# Altium schematic sheet EVB-NORA-B2_SCHEM_0005_On-Board-Debug.SchDoc(EVB-NORA-B2_SCHEM_0005_On-Board-Debug)
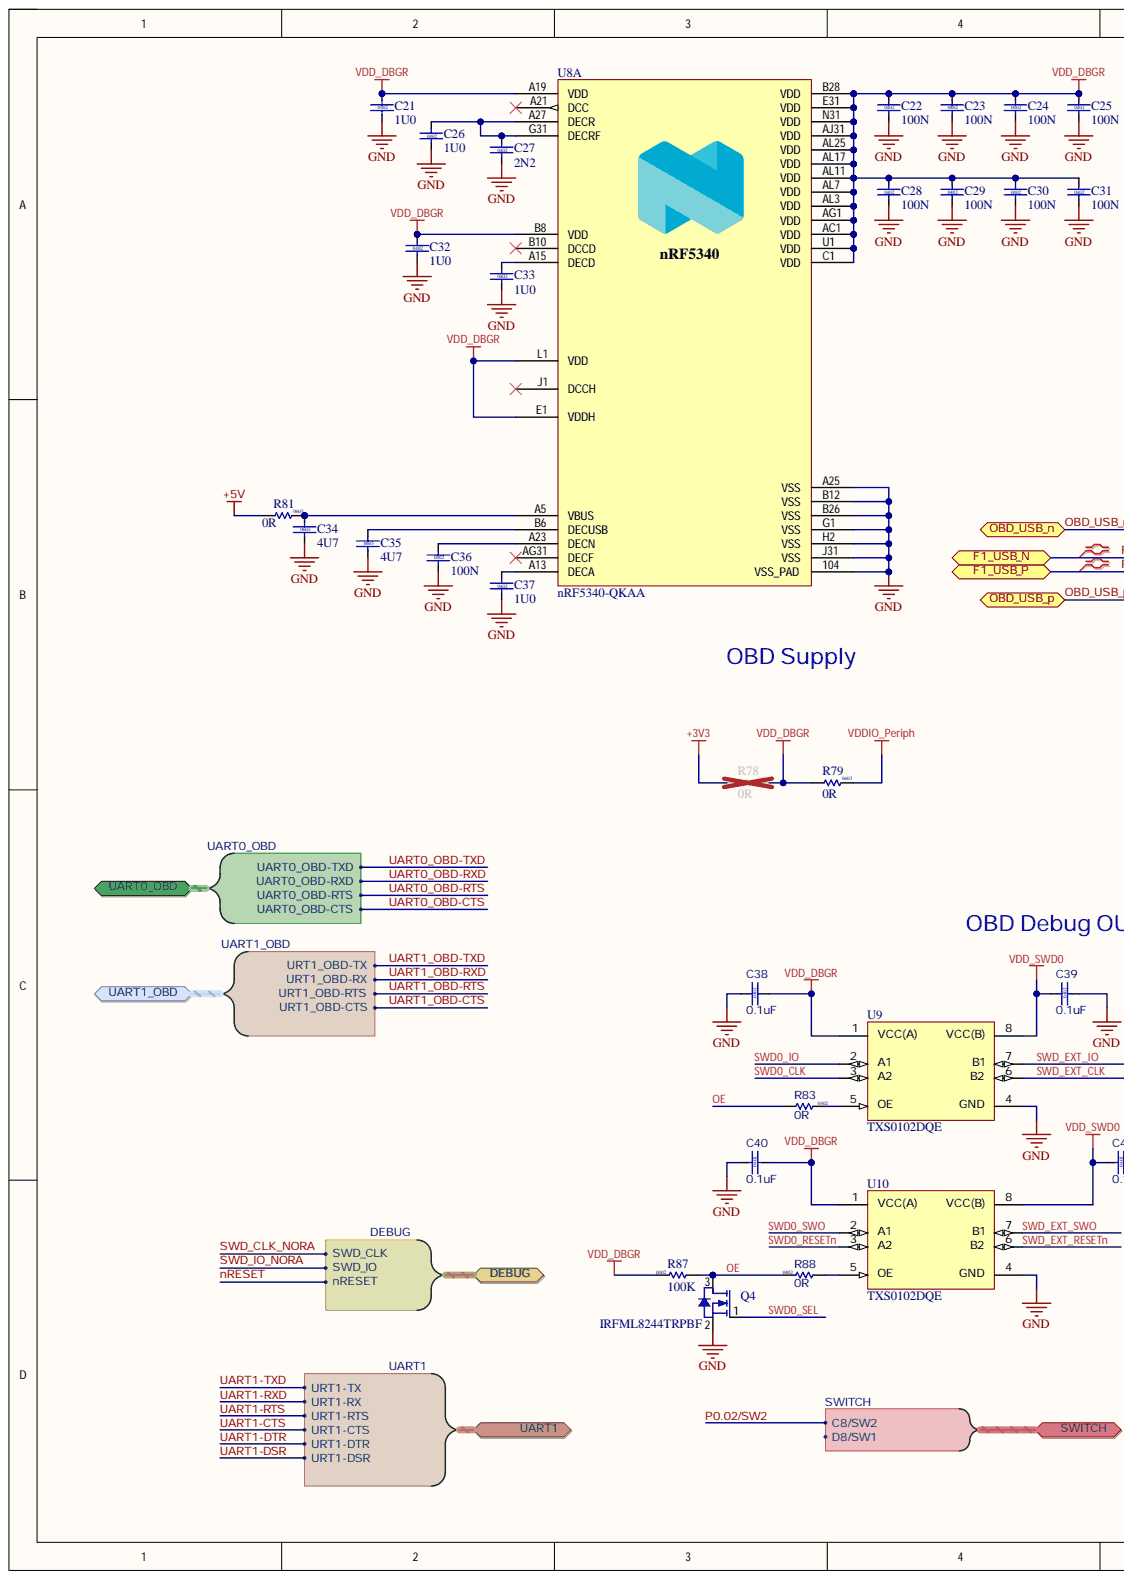
[diagram p0: EVB-NORA-B2_SCHEM_0005_On-Board-Debug.SchDoc, left]
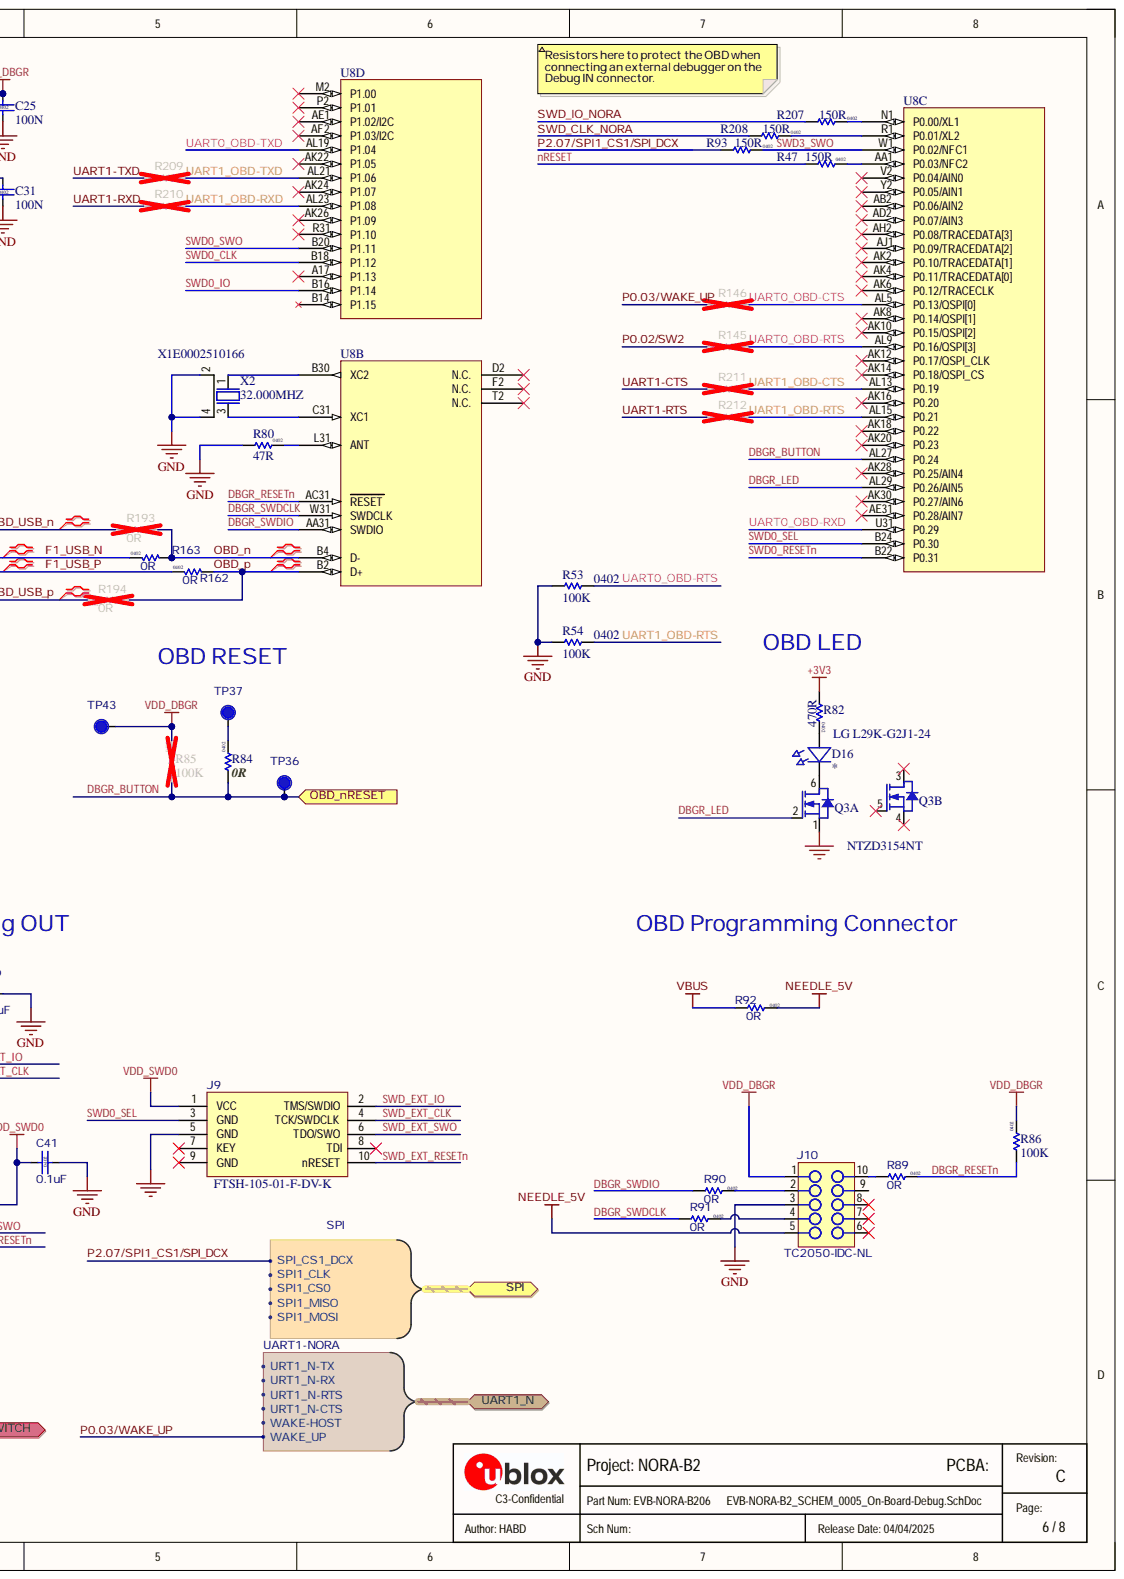
[diagram p1: EVB-NORA-B2_SCHEM_0005_On-Board-Debug.SchDoc, right]

## Parts
C21  value 1U0  Size: 0402  [Cap_0402_1100Lx600Wx600H_600x600Pad_350G_FLX]  (hidden comment: UBXH01-2021003)
C22  value 100N  Size: 0402  [Cap 0402 HD]  (hidden comment: UBXH01-2021002)
C23  value 100N  Size: 0402  [Cap 0402 HD]  (hidden comment: UBXH01-2021002)
C24  value 100N  Size: 0402  [Cap 0402 HD]  (hidden comment: UBXH01-2021002)
C25  value 100N  Size: 0402  [Cap 0402 HD]  (hidden comment: UBXH01-2021002)
C26  value 1U0  Size: 0402  [Cap_0402_1100Lx600Wx600H_600x600Pad_350G_FLX]  (hidden comment: UBXH01-2021003)
C27  value 2N2  Size: 0402  [Cap_0402_1100Lx600Wx600H_600x600Pad_350G_FLX]  (hidden comment: UBXH01-0023017)
C28  value 100N  Size: 0402  [Cap 0402 HD]  (hidden comment: UBXH01-2021002)
C29  value 100N  Size: 0402  [Cap 0402 HD]  (hidden comment: UBXH01-2021002)
C30  value 100N  Size: 0402  [Cap 0402 HD]  (hidden comment: UBXH01-2021002)
C31  value 100N  Size: 0402  [Cap 0402 HD]  (hidden comment: UBXH01-2021002)
C32  value 1U0  Size: 0402  [Cap_0402_1100Lx600Wx600H_600x600Pad_350G_FLX]  (hidden comment: UBXH01-2021003)
C33  value 1U0  Size: 0402  [Cap_0402_1100Lx600Wx600H_600x600Pad_350G_FLX]  (hidden comment: UBXH01-2021003)
C34  value 4U7  Size: 0603  [CAP_0603_1750Lx950Wx850H_600x1000Pad_900G_FLX]  (hidden comment: UBXH01-2002003)
C35  value 4U7  Size: 0603  [CAP_0603_1750Lx950Wx850H_600x1000Pad_900G_FLX]  (hidden comment: UBXH01-2002003)
C36  value 100N  Size: 0402  [Cap 0402 HD]  (hidden comment: UBXH01-2021002)
C37  value 1U0  Size: 0402  [Cap_0402_1100Lx600Wx600H_600x600Pad_350G_FLX]  (hidden comment: UBXH01-2021003)
C38  value 0.1uF  Size: 0402  [Cap 0402 HD]  (hidden comment: 120-00016)
C39  value 0.1uF  Size: 0402  [Cap 0402 HD]  (hidden comment: 120-00016)
C40  value 0.1uF  Size: 0402  [Cap 0402 HD]  (hidden comment: 120-00016)
C41  value 0.1uF  Size: 0402  [Cap 0402 HD]  (hidden comment: 120-00016)
D16  Manufacturer P/N: LG L29K-G2J1-24  [LED_0603_1600Lx800Wx400H_600x800Pad_800G_FLX]  (hidden comment: UBXH11-0000018)
J9  Manufacturer P/N: FTSH-105-01-F-DV-K  [HDR.050P-10Pin-2x5-SMT_Key]  (hidden comment: 130-00099)
J10  TC2050-IDC-NL  [TC2050-IDC-NL]  (no BOM)
Q3  value NTZD3154NT  Manufacturer P/N: NTZD3154NT  [SOT-563]  (hidden comment: UBXH20-0000083)  (parts A,B)
Q4  value IRFML8244TRPBF  Manufacturer P/N: IRFML8244TRPBF  [SOT-23]  (hidden comment: UBXH20-0000036)
R47  value 150R  Size: 0402  [RES_0402_1100Lx600Wx400H_500x600Pad_400G_FLX]  (hidden comment: UBXH18-0008029)
R53  value 100K  Size: 0402  [RES 0402 HD]
R54  value 100K  Size: 0402  [RES 0402 HD]
R78  value 0R  Size: 0603  [RES_0603_1700Lx950Wx600H_600x1000Pad_800G_FLX]
R79  value 0R  Size: 0603  [RES_0603_1700Lx950Wx600H_600x1000Pad_800G_FLX]  (hidden comment: UBXH18-0003001)
R80  value 47R  Size: 0402  [RES_0402_1100Lx600Wx400H_500x600Pad_400G_FLX]  (hidden comment: UBXH18-0008017)
R81  value 0R  Size: 0603  [RES_0603_1700Lx950Wx600H_600x1000Pad_800G_FLX]  (hidden comment: UBXH18-0003001)
R82  value 470R  Size: 0402  [RES_0402_1100Lx600Wx400H_500x600Pad_400G_FLX]  (hidden comment: UBXH18-0008041)
R83  value 0R  Size: 0402  [RES 0402 HD]  (hidden comment: UBXH18-0003002)
R84  value 0R  Size: 0402  [RES_0402_1100Lx600Wx400H_500x600Pad_400G_FLX]
R84
R84
R84
R84
R85  value 100K  Size: 0402  [RES 0402 HD]
R86  value 100K  Size: 0402  [RES 0402 HD]  (hidden comment: UBXH18-0000005)
R87  value 100K  Size: 0402  [RES 0402 HD]  (hidden comment: UBXH18-0000005)
R88  value 0R  Size: 0402  [RES 0402 HD]  (hidden comment: UBXH18-0003002)
R89  value 0R  Size: 0402  [RES 0402 HD]  (hidden comment: UBXH18-0003002)
R90  value 0R  Size: 0402  [RES 0402 HD]  (hidden comment: UBXH18-0003002)
R91  value 0R  Size: 0402  [RES 0402 HD]  (hidden comment: UBXH18-0003002)
R92  value 0R  Size: 0402  [RES 0402 HD]  (hidden comment: UBXH18-0003002)
R93  value 150R  Size: 0402  [RES_0402_1100Lx600Wx400H_500x600Pad_400G_FLX]  (hidden comment: UBXH18-0008029)
R145  = "Part Number" + Value + DNP  [RES 0402 HD]
R146  = "Part Number" + Value + DNP  [RES 0402 HD]
R162  value 0R  Size: 0402  [RES 0402 HD]
R163  value 0R  Size: 0402  [RES 0402 HD]
R193  value 0R  Size: 0402  [RES 0402 HD]
R194  value 0R  Size: 0402  [RES 0402 HD]
R207  value 150R  Size: 0402  [RES_0402_1100Lx600Wx400H_500x600Pad_400G_FLX]  (hidden comment: UBXH18-0008029)
R208  value 150R  Size: 0402  [RES_0402_1100Lx600Wx400H_500x600Pad_400G_FLX]  (hidden comment: UBXH18-0008029)
R209  UBXH18-0003002  [RES 0402 HD]  (value not drawn)
R210  UBXH18-0003002  [RES 0402 HD]  (value not drawn)
R211  UBXH18-0003002  [RES 0402 HD]  (value not drawn)
R212  UBXH18-0003002  [RES 0402 HD]  (value not drawn)
TP36  TP10R  [TP10R]  (value not drawn)  (no BOM)
TP37  TP10R  [TP10R]  (value not drawn)  (no BOM)
TP43  TP10R  [TP10R]  (value not drawn)  (no BOM)
U8  value nRF5340-QKAA  Manufacturer P/N: nRF5340-QKAA  [nRF5340_AQFN94]  (hidden comment: UBXH12-0000420)  (parts A,B,C,D)
U9  value TXS0102DQE  Manufacturer P/N: TXS0102DQE  [DQE0008A]  (hidden comment: UBXH10-0000108)
U10  value TXS0102DQE  Manufacturer P/N: TXS0102DQE  [DQE0008A]  (hidden comment: UBXH10-0000108)
X2  value 32.000MHZ  Manufacturer P/N: X1E0002510166  [XTAL_1612]  (hidden comment: UBXH21-0000240)

## Ports
DEBUG, DEBUG.nRESET, DEBUG.SWD_CLK, DEBUG.SWD_IO, F1_USB_N, F1_USB_P, OBD_nRESET, OBD_USB_n, OBD_USB_p, SPI, SPI.SPI1_CLK, SPI.SPI1_CS0, SPI.SPI1_MISO, SPI.SPI1_MOSI, SPI.SPI_CS1_DCX, SWITCH, SWITCH.C8/SW2, SWITCH.D8/SW1, UART0_OBD, UART0_OBD.UART0_OBD-CTS, UART0_OBD.UART0_OBD-RTS, UART0_OBD.UART0_OBD-RXD, UART0_OBD.UART0_OBD-TXD, UART1, UART1.URT1-CTS, UART1.URT1-DSR, UART1.URT1-DTR, UART1.URT1-RTS, UART1.URT1-RX, UART1.URT1-TX, UART1_N, UART1_N.URT1_N-CTS, UART1_N.URT1_N-RTS, UART1_N.URT1_N-RX, UART1_N.URT1_N-TX, UART1_N.WAKE-HOST, UART1_N.WAKE_UP, UART1_OBD, UART1_OBD.URT1_OBD-CTS, UART1_OBD.URT1_OBD-RTS, UART1_OBD.URT1_OBD-RX, UART1_OBD.URT1_OBD-TX

## Nets
+3V3: R78.1 R82.1
+5V: R81.1
GND: C21.2 C22.2 C23.2 C24.2 C25.2 C26.2 C27.2 C28.2 C29.2 C30.2 C31.2 C32.2 C33.2 C34.2 C35.2 C36.2 C37.2 C38.1 C39.2 C40.1 C41.2 J9.5(GND) J10.3 Q3.1 Q4.2 R53.1 R54.1 R80.1 U8.104(VSS_PAD) U8.A25(VSS) U8.B12(VSS) U8.B26(VSS) U8.G1(VSS) U8.H2(VSS) U8.J31(VSS) U9.4(GND) U10.4(GND) X2.2 X2.4
NEEDLE_5V: J10.5 R92.1
VBUS: R92.2
VDD_DBGR: C21.1 C22.1 C23.1 C24.1 C25.1 C28.1 C29.1 C30.1 C31.1 C32.1 C38.2 C40.2 J10.1 R78.2 R79.1 R85.2 R86.2 R87.2 TP43.1 U8.A19(VDD) U8.AC1(VDD) U8.AG1(VDD) U8.AJ31(VDD) U8.AL3(VDD) U8.AL7(VDD) U8.AL11(VDD) U8.AL17(VDD) U8.AL25(VDD) U8.B8(VDD) U8.B28(VDD) U8.C1(VDD) U8.E1(VDDH) U8.E31(VDD) U8.L1(VDD) U8.N31(VDD) U8.U1(VDD) U9.1(VCC(A)) U10.1(VCC(A))
VDD_SWD0: C39.1 C41.1 J9.1(VCC) U9.8(VCC(B)) U10.8(VCC(B))
VDDIO_Periph: R79.2
DBGR_BUTTON: R84.1 R85.1 TP36.1 U8.AL27(P0.24) ; port OBD_nRESET
DBGR_LED: Q3.2 U8.AL29(P0.26/AIN5)
DBGR_RESETn: R86.1 R89.1 U8.AC31(RESET)
DBGR_SWDCLK: R91.2 U8.W31(SWDCLK)
DBGR_SWDIO: R90.2 U8.AA31(SWDIO)
F1_USB_N: R163.2 ; port F1_USB_N
F1_USB_P: R162.2 ; port F1_USB_P
nRESET: R47.1 ; port DEBUG
OBD_n: R163.1 R193.1 U8.B4(D-)
OBD_p: R162.1 R194.1 U8.B2(D+)
OBD_USB_n: R193.2 ; port OBD_USB_n
OBD_USB_p: R194.2 ; port OBD_USB_p
OE: Q4.3 R83.2 R87.1 R88.1
P0.02/SW2: R145.2 ; port SWITCH
P0.03/WAKE_UP: R146.2 ; port UART1_N
P2.07/SPI1_CS1/SPI_DCX: R93.1 ; port SPI
SWD0_CLK: U8.B18(P1.12) U9.3(A2)
SWD0_IO: U8.B16(P1.14) U9.2(A1)
SWD0_RESETn: U8.B22(P0.31) U10.3(A2)
SWD0_SEL: J9.3(GND) Q4.1 U8.B24(P0.30)
SWD0_SWO: U8.B20(P1.11) U10.2(A1)
SWD3_SWO: R93.2 U8.W1(P0.02/NFC1)
SWD_CLK_NORA: R208.1 ; port DEBUG
SWD_EXT_CLK: J9.4(TCK/SWDCLK) U9.6(B2)
SWD_EXT_IO: J9.2(TMS/SWDIO) U9.7(B1)
SWD_EXT_RESETn: J9.10(nRESET) U10.6(B2)
SWD_EXT_SWO: J9.6(TDO/SWO) U10.7(B1)
SWD_IO_NORA: R207.1 ; port DEBUG
UART0_OBD-CTS: R146.1 U8.AL5(P0.13/QSPI[0]) ; port UART0_OBD
UART0_OBD-RTS: R53.2 R145.1 U8.AL9(P0.16/QSPI[3]) ; port UART0_OBD
UART0_OBD-RXD: U8.U31(P0.29) ; port UART0_OBD
UART0_OBD-TXD: U8.AL19(P1.04) ; port UART0_OBD
UART1-CTS: R211.2 ; port UART1
UART1-RTS: R212.2 ; port UART1
UART1-RXD: R210.2 ; port UART1
UART1-TXD: R209.2 ; port UART1
UART1_OBD-CTS: R211.1 U8.AL13(P0.19) ; port UART1_OBD
UART1_OBD-RTS: R54.2 R212.1 U8.AL15(P0.21) ; port UART1_OBD
UART1_OBD-RXD: R210.1 U8.AL23(P1.08) ; port UART1_OBD
UART1_OBD-TXD: R209.1 U8.AL21(P1.06) ; port UART1_OBD
NetC26_1: C26.1 C27.1 U8.A27(DECR) U8.G31(DECRF)
NetC33_1: C33.1 U8.A15(DECD)
NetC34_1: C34.1 R81.2 U8.A5(VBUS)
NetC35_1: C35.1 U8.B6(DECUSB)
NetC36_1: C36.1 U8.A23(DECN)
NetC37_1: C37.1 U8.A13(DECA)
NetD16_1: D16.1 Q3.6
NetD16_2: D16.2 R82.2
NetJ10_2: J10.2 R90.1
NetJ10_4: J10.4 R91.1
NetJ10_10: J10.10 R89.2
NetR47_2: R47.2 U8.AA1(P0.03/NFC2)
NetR80_2: R80.2 U8.L31(ANT)
NetR83_1: R83.1 U9.5(OE)
NetR84_2: R84.2 TP37.1
NetR88_2: R88.2 U10.5(OE)
NetR207_2: R207.2 U8.N1(P0.00/XL1)
NetR208_2: R208.2 U8.R1(P0.01/XL2)
NetU8_B30: U8.B30(XC2) X2.1
NetU8_C31: U8.C31(XC1) X2.3
N00001: ; port SPI
N00003: ; port SPI
N00005: ; port SPI
N00007: ; port SPI
N00012: ; port SWITCH
N00013: ; port UART1_N
N00014: ; port UART1_N
N00015: ; port UART1_N
N00016: ; port UART1_N
N00017: ; port UART1_N
UART1-DSR: ; port UART1
UART1-DTR: ; port UART1

Not connected: J9 7(KEY) 8(TDI) 9(GND); J10 6 7 8 9; Q3 3 4 5; U8 A17(P1.13) A21(DCC) AB2(P0.06/AIN2) AD2(P0.07/AIN3) AE1(P1.02/I2C) AE31(P0.28/AIN7) AF2(P1.03/I2C) AG31(DECF) AH2(P0.08/TRACEDATA[3]) AJ1(P0.09/TRACEDATA[2]) AK2(P0.10/TRACEDATA[1]) AK4(P0.11/TRACEDATA[0]) AK6(P0.12/TRACECLK) AK8(P0.14/QSPI[1]) AK10(P0.15/QSPI[2]) AK12(P0.17/QSPI_CLK) AK14(P0.18/QSPI_CS) AK16(P0.20) AK18(P0.22) AK20(P0.23) AK22(P1.05) AK24(P1.07) AK26(P1.09) AK28(P0.25/AIN4) AK30(P0.27/AIN6) B10(DCCD) B14(P1.15) D2(N.C.) F2(N.C.) J1(DCCH) M2(P1.00) P2(P1.01) R31(P1.10) T2(N.C.) V2(P0.04/AIN0) Y2(P0.05/AIN1)

## Library data of the parts
C21 C26 C32 C33 C37 = UBXH01-2021003: CAP CER X5R 0402 1U0 10% 6.3V; Manufacturer: Murata Manufacturing Company, Ltd.; Manufacturer P/N: GRM155R61C105KA12; Database Table Name: Passive - Capacitor; Editor: philippe.largier; Env: GREEN; Mfg P/N Released: Yes; Mfg P/N Split: 100; Mfg P/N Status: Active; Perferred: PREF; Permitted Use: Volume Products; Released by: alvaro.nussbaum; Component Kind: Standard; Library Name: UBX_Parts_DB_Lib.DbLib; Manufacturer 2: Samsung Electro-Mechanics; Manufacturer 3: Taiyo Yuden Company, Ltd.; Manufacturer P/N 2: CL05A105KA5NNN; Manufacturer P/N 3: JMK105BJ105KV-F; Max Voltage: 6.3V; Mfg P/N Datasheet: https://wiki.u-blox.com/bin/view/Generic/Docs/DocumentSummary?index=29581; Mfg P/N Datasheet 2: https://wiki.u-blox.com/bin/view/Generic/Docs/DocumentSummary?index=28197; Mfg P/N Datasheet 3: https://wiki.u-blox.com/bin/view/Generic/Docs/DocumentSummary?index=20234; Mfg P/N Released 2: Yes; Mfg P/N Released 3: Yes; Mfg P/N Split 2: 0; Mfg P/N Split 3: 0; Mfg P/N Status 2: Active; Mfg P/N Status 3: NRND; Part Number: UBXH01-2021003; Part Type: Capacitor; RefDes: C; Release Data: 2016-02-02T16:25:07; Signal Integrity: Cap Semi; Simulation: CAP; Tolerance: 10%; UBX Wiki: https://wiki.u-blox.com/bin/view/Operations/PartDetails?index=5761
C22-C25 C28-C31 C36 = UBXH01-2021002: CAP CER X5R 0402 100N 10% 10V; Manufacturer: Murata Manufacturing Company, Ltd.; Manufacturer P/N: GRM155R61A104KA01; Database Table Name: Passive - Capacitor; Editor: roland.rust; Env: GREEN; Mfg P/N Released: Yes; Mfg P/N Split: 100; Mfg P/N Status: NRND; Perferred: PREF; Permitted Use: Volume Products; Component Kind: Standard; Library Name: UBX_Parts_DB_Lib.DbLib; Manufacturer 2: Samsung Electro-Mechanics; Manufacturer P/N 2: CL05A104KP5NNN; Max Voltage: 10V; Mfg P/N Datasheet 2: https://wiki.u-blox.com/bin/view/Generic/Docs/DocumentSummary?index=28113; Mfg P/N Released 2: Yes; Mfg P/N Split 2: 0; Mfg P/N Status 2: Active; Part Number: UBXH01-2021002; Part Type: Capacitor; RefDes: C; Release Data: 2005-07-22T13:59:44; Signal Integrity: Cap Semi; Simulation: CAP; Tolerance: 10%; UBX Wiki: https://wiki.u-blox.com/bin/view/Operations/PartDetails?index=5759; Released by: roland.rust
C27 = UBXH01-0023017: CAP CER X7R 0402 2N2 10% 16V; Manufacturer: Murata Manufacturing Company, Ltd.; Manufacturer P/N: GRM155R71C222KA01; Database Table Name: Passive - Capacitor; Editor: roland.rust; Env: ROHS; Mfg P/N Released: Yes; Mfg P/N Split: 100; Mfg P/N Status: NRND; Perferred: PREF; Permitted Use: Volume Products; Component Kind: Standard; Library Name: UBX_Parts_DB_Lib.DbLib; Max Voltage: 16V; Part Number: UBXH01-0023017; Part Type: Capacitor; RefDes: C; Release Data: 2005-07-22T13:59:44; Signal Integrity: Cap Semi; Simulation: CAP; Tolerance: 10%; UBX Wiki: https://wiki.u-blox.com/bin/view/Operations/PartDetails?index=5475; Released by: roland.rust
C34 C35 = UBXH01-2002003: CAP CER X5R 0603 4U7 10% 6.3V; Manufacturer: Murata Manufacturing Company, Ltd.; Manufacturer P/N: GRM188R61C475KE11; Database Table Name: Passive - Capacitor; Editor: philippe.largier; Env: GREEN; Mfg P/N Released: Yes; Mfg P/N Split: 100; Mfg P/N Status: Active; Perferred: PREF; Permitted Use: Volume Products; Released by: roland.rust; Component Kind: Standard; Library Name: UBX_Parts_DB_Lib.DbLib; Manufacturer 2: Murata Manufacturing Company, Ltd.; Manufacturer 3: Samsung Electro-Mechanics; Manufacturer P/N 2: GRM188R61E475KE11; Manufacturer P/N 3: CL10A475KO8NNN; Max Voltage: 6.3V; Mfg P/N Datasheet: https://wiki.u-blox.com/bin/view/Generic/Docs/DocumentSummary?index=30154; Mfg P/N Datasheet 2: https://wiki.u-blox.com/bin/view/Generic/Docs/DocumentSummary?index=30500; Mfg P/N Datasheet 3: https://wiki.u-blox.com/bin/view/Generic/Docs/DocumentSummary?index=28177; Mfg P/N Released 2: Yes; Mfg P/N Released 3: Yes; Mfg P/N Split 2: 0; Mfg P/N Split 3: 0; Mfg P/N Status 2: Active; Mfg P/N Status 3: NRND; Part Number: UBXH01-2002003; Part Type: Capacitor; RefDes: C; Release Data: 2016-02-02T16:39:45; Signal Integrity: Cap Semi; Simulation: CAP; Tolerance: 10%; UBX Wiki: https://wiki.u-blox.com/bin/view/Operations/PartDetails?index=5742
C38-C41 = 120-00016: Cap, Ceramic 0.1uF 10V 10% X5R 0402; Manufacturer: Murata Electronics North America; Manufacturer P/N: GRM155R61A104KA01J; Part Name: CAP CER 0.1UF 10V 10% X5R 0402; Component Mounting Type: SMT; Database Table Name: Ceramic Capacitor; Item_Phase: Production; Tolerance: +/- 10%; Use in new designs: Yes; Arena Link: https://app.bom.com/a/GYHPV2L2L43KRMBK?v=spec.summary; Component Kind: Standard; Height: 0.55mm; Manufacturer 2: Yageo; Manufacturer P/N 2: CC0402KRX5R6BB104; Part Number: 120-00016; Part_Type: Ceramic Capacitor; Pins: 2; Revision: 0A; Signal Integrity: Cap Semi; Simulation: CAP; Supplier 1: Digi-Key; Supplier 2: Digi-Key; Supplier Part Number 1: 490-6297-1-ND; Supplier Part Number 2: 311-1336-1-ND; Temperature Coefficient: X5R; Voltage: 10V; Library Name: Arena_Parts_DB_Lib.DbLib
D16 = UBXH11-0000018: LED CHIP 0603 LOW CURRENT OSRAM 0.002A GREEN 2.2V 2mA; Database Table Name: Discrete - LED; Editor: johann.furrer; Env: ROHS; Mfg P/N Released: Yes; Mfg P/N Split: 100; Mfg P/N Status: NRND; Perferred: PREF; Permitted Use: Volume Products; Released by: martin.grasse; Component Kind: Standard; Library Name: UBX_Parts_DB_Lib.DbLib; Manufacturer: Osram; Max Current: 2mA; Max Operating Temp: 85°C; Max Voltage: 2.2V; Mfg P/N Datasheet: https://wiki.u-blox.com/bin/view/Generic/Docs/DocumentSummary?index=20620; Min Operating Temp: -40°C; Part Number: UBXH11-0000018; Part Type: LED; RefDes: DS; Release Data: 2018-01-09T15:31:38; Size: 0603; UBX Wiki: https://wiki.u-blox.com/bin/view/Operations/PartDetails?index=20619; Value: GREEN
J9 = 130-00099: Header 0.05" 10Pin 2Row SMD Black; Manufacturer: Samtec; Part Name: CON HDR 0.05" 10Pin 2Row SMD Black; Component Mounting Type: SMT; Database Table Name: Connector; Item_Phase: Pre-Production; Keyed: Yes; Locking: No; Pin Pitch: 0.050"; Rows: 2; Arena Link: https://app.bom.com/a/5N6EKRARATS7TSHL?v=spec.summary; Color: Black; Component Kind: Standard; Connector Type: Header; Height: 6mm; Length: 12.75mm; Part Number: 130-00099; Part_Type: Connector; Pins: 10; Revision: 1; Supplier 1: Digi-Key; Supplier Part Number 1: SAM8796-ND; Use in new designs: Yes; Width: 4.78; Library Name: Arena_Parts_DB_Lib.DbLib
J10 = TC2050-IDC-NL: CON PADS FOR CBL PLUG-OF-NAILS 10-PIN NO LEGS; Manufacturer: TAG Connect; Added By: Paul B; Database Table Name: Testpoints & Pads; Added Date: 12/3/2015; DNP: DNP; Height: 0; Manufacturer P/N: TC2050-IDC-NL; Part Number: TC2050-IDC-NL; Size: 6.1mm x 9.9mm; Library Name: LEGACY_Rigado_AltiumLib.DbLib
Q3 = UBXH20-0000083: DUAL N-CHANNEL SMALL SIGNAL MOSFET SOT-563-6 PACKAGE; Manufacturer: ON Semiconductor; Database Table Name: Discrete - Transistor; Editor: pierpaolo.arban; Env: ROHS; Mfg P/N Released: Yes; Mfg P/N Split: 100; Mfg P/N Status: Active; Perferred: PREF; Permitted Use: Support Products; Released by: matej.budin; Component Kind: Standard; Library Name: UBX_Parts_DB_Lib.DbLib; Max Operating Temp: 155°C; Mfg P/N Datasheet: https://wiki.u-blox.com/bin/view/Generic/Docs/DocumentSummary?index=37718; Min Operating Temp: -55°C; Part Number: UBXH20-0000083; Part Type: Transistor; RefDes: Q; Release Data: 2023-01-26T11:57:34; UBX Wiki: https://wiki.u-blox.com/bin/view/Operations/PartDetails?index=37715
Q4 = UBXH20-0000036: N-CHANNEL 25V HEXFET SOT23 25V 5.8A; Manufacturer: IR International Rectifier; Database Table Name: Discrete - Transistor; Editor: martin.kessel; Env: ROHS; Mfg P/N Released: No; Mfg P/N Split: 100; Mfg P/N Status: Active; Perferred: PREF; Permitted Use: Internal Use; Component Kind: Standard; Library Name: UBX_Parts_DB_Lib.DbLib; Max Current: 5.8A; Max Operating Temp: 125°C; Max Voltage: 25V; Mfg P/N Datasheet: https://wiki.u-blox.com/bin/view/Generic/Docs/DocumentSummary?index=20559; Min Operating Temp: -55°C; Part Number: UBXH20-0000036; Part Type: Transistor; RefDes: Q; Simulation: NMOS; UBX Wiki: https://wiki.u-blox.com/bin/view/Operations/PartDetails?index=20556
R47 R93 R207 R208 = UBXH18-0008029: RES THICK FILM CHIP 0402 150R 5% 63mW; Manufacturer: KOA; Manufacturer P/N: RK73B1ETTP151J; Database Table Name: Passive - Resistor; Editor: par.hakansson; Env: ROHS; Mfg P/N Released: Yes; Mfg P/N Split: 100; Mfg P/N Status: Active; Perferred: PREF; Permitted Use: Volume Products; Released by: adam.johansson; Case/Package: 0x0y; Component Kind: Standard; Library Name: UBX_Parts_DB_Lib.DbLib; Max Operating Temp: 125°C; Max Power: 63mW; Mfg P/N Datasheet: https://wiki.u-blox.com/bin/view/Generic/Docs/DocumentSummary?index=4543; Min Operating Temp: -55°C; Part Number: UBXH18-0008029; Part Type: Resistor; RefDes: R; Release Data: 2015-11-05T14:33:12; Signal Integrity: Res; Simulation: RESISTOR; Tolerance: 5%; UBX Wiki: https://wiki.u-blox.com/bin/view/Operations/PartDetails?index=6908
R53 R54 R85-R87 = UBXH18-0000005: RES THICK FILM CHIP 0402 100K 1% 63mW; Manufacturer: KOA; Manufacturer P/N: RK73H1ETTP1003F; Database Table Name: Passive - Resistor; Editor: dario.antonaz; Env: ROHS; Mfg P/N Released: Yes; Mfg P/N Split: 100; Mfg P/N Status: Active; Permitted Use: Volume Products; Released by: sandro.sestan; Component Kind: Standard; Library Name: UBX_Parts_DB_Lib.DbLib; Max Power: 63mW; Mfg P/N Datasheet: https://wiki.u-blox.com/bin/view/Generic/Docs/DocumentSummary?index=8222; Mfg P/N Released 2: Yes; Mfg P/N Split 2: 0; Part Number: UBXH18-0000005; Part Type: Resistor; Perferred: N/A; RefDes: R; Release Data: 2009-11-30T11:05:22; Signal Integrity: Res; Simulation: RESISTOR; Tolerance: 1%; UBX Wiki: https://wiki.u-blox.com/bin/view/Operations/PartDetails?index=8114
  R53 R54: Case/Package: 0x0y; Comment: = "Part Number" + Value + DNP; Manufacturer 2: YAGEO; Manufacturer 3: ROHM; Manufacturer P/N 2: RC0402FR-07100KL; Manufacturer P/N 3: MCR01MZPF1003; Mfg P/N Datasheet 2: https://wiki.u-blox.com/bin/view/Generic/Docs/DocumentSummary?index=29373; Mfg P/N Datasheet 3: https://wiki.u-blox.com/bin/view/Generic/Docs/DocumentSummary?index=8186; Mfg P/N Released 3: Yes; Mfg P/N Split 3: 0; Mfg P/N Status 2: Active; Mfg P/N Status 3: Obsolete
  R85: Comment: = "Part Number" + Value + DNP; Manufacturer 2: ROHM; Manufacturer P/N 2: MCR01MZPF1003; Mfg P/N Datasheet 2: https://wiki.u-blox.com/bin/view/Generic/Docs/DocumentSummary?index=8186; Mfg P/N Status 2: Obsolete
  R86 R87: Manufacturer 2: ROHM; Manufacturer P/N 2: MCR01MZPF1003; Mfg P/N Datasheet 2: https://wiki.u-blox.com/bin/view/Generic/Docs/DocumentSummary?index=8186; Mfg P/N Status 2: Obsolete
R78 R79 R81 = UBXH18-0003001: RES THICK FILM CHIP 0603 0R 0 100mW; Manufacturer: YAGEO; Manufacturer P/N: RC0603JR-070RL; Database Table Name: Passive - Resistor; Editor: martin.frauchiger; Env: ROHS; Mfg P/N Released: Yes; Mfg P/N Split: 100; Mfg P/N Status: Active; Perferred: PREF; Permitted Use: Volume Products; Tolerance: 0; Component Kind: Standard; Library Name: UBX_Parts_DB_Lib.DbLib; Max Power: 100mW; Part Number: UBXH18-0003001; Part Type: Resistor; RefDes: R; Release Data: 2005-07-14T10:55:51; Signal Integrity: Res; Simulation: RESISTOR; UBX Wiki: https://wiki.u-blox.com/bin/view/Operations/PartDetails?index=6609; Released by: martin.frauchiger
  R78: Comment: = "Part Number" + Value + DNP
R80 = UBXH18-0008017: RES THICK FILM CHIP 0402 47R 5% 100mW; Manufacturer: YAGEO; Manufacturer P/N: 2322_705_87479_L; Database Table Name: Passive - Resistor; Editor: roland.rust; Env: ROHS; Mfg P/N Released: Yes; Mfg P/N Split: 100; Mfg P/N Status: Obsolete; Perferred: PREF; Permitted Use: Volume Products; Component Kind: Standard; Library Name: UBX_Parts_DB_Lib.DbLib; Manufacturer 2: YAGEO; Manufacturer P/N 2: RC0402JR-0747RL; Max Power: 100mW; Mfg P/N Datasheet 2: https://wiki.u-blox.com/bin/view/Generic/Docs/DocumentSummary?index=8163; Mfg P/N Released 2: No; Mfg P/N Split 2: 0; Mfg P/N Status 2: Active; Part Number: UBXH18-0008017; Part Type: Resistor; RefDes: R; Release Data: 2007-01-03T17:15:04; Signal Integrity: Res; Simulation: RESISTOR; Tolerance: 5%; UBX Wiki: https://wiki.u-blox.com/bin/view/Operations/PartDetails?index=6891; Released by: roland.rust
R82 = UBXH18-0008041: RES THICK FILM CHIP 0402 470R 5% 100mW; Manufacturer: KOA; Manufacturer P/N: RK73B1ETTP471J; Database Table Name: Passive - Resistor; Editor: roland.rust; Env: ROHS; Mfg P/N Released: Yes; Mfg P/N Split: 100; Mfg P/N Status: Active; Perferred: PREF; Permitted Use: Volume Products; Component Kind: Standard; Library Name: UBX_Parts_DB_Lib.DbLib; Manufacturer 2: ROHM; Manufacturer 3: YAGEO; Manufacturer P/N 2: MCR01MZPJ471; Manufacturer P/N 3: 2322_705_87471_L; Max Power: 100mW; Mfg P/N Released 2: Yes; Mfg P/N Released 3: Yes; Mfg P/N Split 2: 0; Mfg P/N Split 3: 0; Mfg P/N Status 2: Obsolete; Mfg P/N Status 3: Obsolete; Part Number: UBXH18-0008041; Part Type: Resistor; RefDes: R; Release Data: 2009-04-24T14:36:39; Signal Integrity: Res; Simulation: RESISTOR; Tolerance: 5%; UBX Wiki: https://wiki.u-blox.com/bin/view/Operations/PartDetails?index=6926; Released by: roland.rust
R83 R88-R92 R162 R163 = UBXH18-0003002: RES THICK FILM CHIP 0402 0R 0 100mW; Manufacturer: KOA; Manufacturer P/N: RK73Z1ETTP; Database Table Name: Passive - Resistor; Editor: charlotte.biber; Env: ROHS; Mfg P/N Released: Yes; Mfg P/N Split: 100; Mfg P/N Status: NRND; Perferred: PREF; Permitted Use: Volume Products; Released by: peter.mueller; Tolerance: 0; Component Kind: Standard; Library Name: UBX_Parts_DB_Lib.DbLib; Manufacturer 2: YAGEO; Manufacturer P/N 2: 2322_705_91001_L; Max Power: 100mW; Mfg P/N Released 2: Yes; Mfg P/N Split 2: 0; Mfg P/N Status 2: NRND; Part Number: UBXH18-0003002; Part Type: Resistor; RefDes: R; Release Data: 2012-06-05 09:49:55.978616; Signal Integrity: Res; Simulation: RESISTOR; UBX Wiki: https://wiki.u-blox.com/bin/view/Operations/PartDetails?index=6611
  R162 R163: Comment: = "Part Number" + Value + DNP
R84 R145 R146 R193 R194 R209-R212 = UBXH18-0003002: RES THICK FILM CHIP 0402 0R 0 100mW; Manufacturer: KOA; Manufacturer P/N: RK73Z1ETTD; Database Table Name: Passive - Resistor; Editor: charlotte.biber; Env: ROHS; Mfg P/N Released: Yes; Mfg P/N Split: 100; Mfg P/N Status: NRND; Perferred: PREF; Permitted Use: Volume Products; Released by: peter.mueller; Tolerance: 0; Component Kind: Standard; Library Name: UBX_Parts_DB_Lib.DbLib; Manufacturer 2: YAGEO; Manufacturer P/N 2: 2322_705_91001_L; Max Power: 100mW; Mfg P/N Released 2: Yes; Mfg P/N Split 2: 0; Part Number: UBXH18-0003002; Part Type: Resistor; RefDes: R; Signal Integrity: Res; Simulation: RESISTOR; UBX Wiki: https://wiki.u-blox.com/bin/view/Operations/PartDetails?index=6611
  R84: Comment: = "Part Number" + Value + DNP; Mfg P/N Datasheet: https://wiki.u-blox.com/bin/view/Generic/Docs/DocumentSummary?index=8222; Mfg P/N Datasheet 2: https://wiki.u-blox.com/bin/view/Generic/Docs/DocumentSummary?index=8186; Mfg P/N Status 2: Obsolete; Release Data: 2012-06-05T09:49:55
  R145 R146 R209-R212: Mfg P/N Status 2: NRND; Release Data: 2012-06-05 09:49:55.978616; Size: 0402; Value: 0R
  R193 R194: Comment: = "Part Number" + Value + DNP; Mfg P/N Status 2: NRND; Release Data: 2012-06-05 09:49:55.978616
TP36 TP37 TP43 = TP10R: Testpoint, Round 1.0mm Dia; Added By: Jeremy D; Database Table Name: Testpoints & Pads; Added Date: 2/1/2015; Checked Date: 2/5/2015; DNP: DNP; Height: 0; Part Number: TP10R; Size: 1.0mm; Checked By: Paul B; Library Name: LEGACY_Rigado_AltiumLib.DbLib
U8 = UBXH12-0000420: BT5/802.15.4 SOC NORDIC NRF5340 2.4GHZ; Manufacturer: Nordic Semiconductor; Database Table Name: IC - RF-ASIC; Editor: cam.nichols; Env: ROHS; Mfg P/N Released: Yes; Mfg P/N Split: 100; Mfg P/N Status: Active; Perferred: PREF; Permitted Use: Volume Products; Released by: eric.moog; Component Kind: Standard; ComponentLink1Description: Datasheet; ComponentLink2Description: Supplier link; Library Name: UBX_Parts_DB_Lib.DbLib; Max Operating Temp: 105°C; Mfg P/N Datasheet: https://wiki.u-blox.com/bin/view/Generic/Docs/DocumentSummary?index=32915; Min Operating Temp: -40°C; Part Number: UBXH12-0000420; Part Type: RF-ASIC; RefDes: U; Release Data: 2021-02-15T23:44:47; Single Source: Yes; UBX Wiki: https://wiki.u-blox.com/bin/view/Operations/PartDetails?index=32860
U9 U10 = UBXH10-0000108: TXS0102 2-BIT BIDIRECTIONAL VOLTAGE-LEVEL TRANSLATOR X2SON-8 TXS0102DQE 5.5V; Manufacturer: Texas Instruments; Manufacturer Part Number 1: TXS0102DQET; Body Size: 1.9mm x 0.9mm; Current: None; Database Table Name: IC - Interface; Editor: eric.moog; Env: ROHS; Item Phase: In Production; Mfg P/N Released: No; Mfg P/N Split: 100; Mfg P/N Status: Active; MFG Package: DSBGA-8; Perferred: PREF; Permitted Use: Support Products; Single Source: Yes; Component Kind: Standard; Height: 0.65mm; Library Name: UBX_Parts_DB_Lib.DbLib; Manufacturer 1: Texas Instruments; Manufacturer 2: Texas Instruments; Manufacturer Part Number 2: TXS0102DQER; Max Operating Temp: 85°C; Max Voltage: 5.5V; Mfg P/N Datasheet: https://wiki.u-blox.com/bin/view/Generic/Docs/DocumentSummary?index=22177; Min Operating Temp: -40°C; Part Name: TXS0102DQE; Part Number: UBXH10-0000108; Part Type: Interface; Pins: 8; RefDes: U; Revision: 0A; UBX Code: None; UBX Wiki: https://wiki.u-blox.com/bin/view/Operations/PartDetails?index=39049; Use in new designs: Yes; Voltage: N/A
X2 = UBXH21-0000240: CRYSTAL 32MHZ CL=8PF 1.6X1.2 MM 32.000MHZ +/-10PPM@25C+/-20PPM@-40/+85+/-1PPMAGEING; Manufacturer: TXC corporation; Database Table Name: Passive - Crystal; Editor: yaqin.chen; Env: ROHS; Mfg P/N Released: Yes; Mfg P/N Split: 100; Mfg P/N Status: Active; Perferred: PREF; Permitted Use: Volume Products; Released by: cam.nichols; Component Kind: Standard; Library Name: UBX_Parts_DB_Lib.DbLib; Max Operating Temp: 85°C; Mfg P/N Datasheet: https://wiki.u-blox.com/bin/view/Generic/Docs/DocumentSummary?index=37320; Min Operating Temp: -40°C; Part Number: UBXH21-0000240; Part Type: Crystal; RefDes: Y; Release Data: 2022-08-10T19:45:48; Tolerance: +/-10PPM@25C+/-20PPM@-40/+85+/-1PPMAGEING; UBX Wiki: https://wiki.u-blox.com/bin/view/Operations/PartDetails?index=37290
